# Altium schematic sheet Fan_Remote_001e.SchDoc(Fan_Remote_001e)
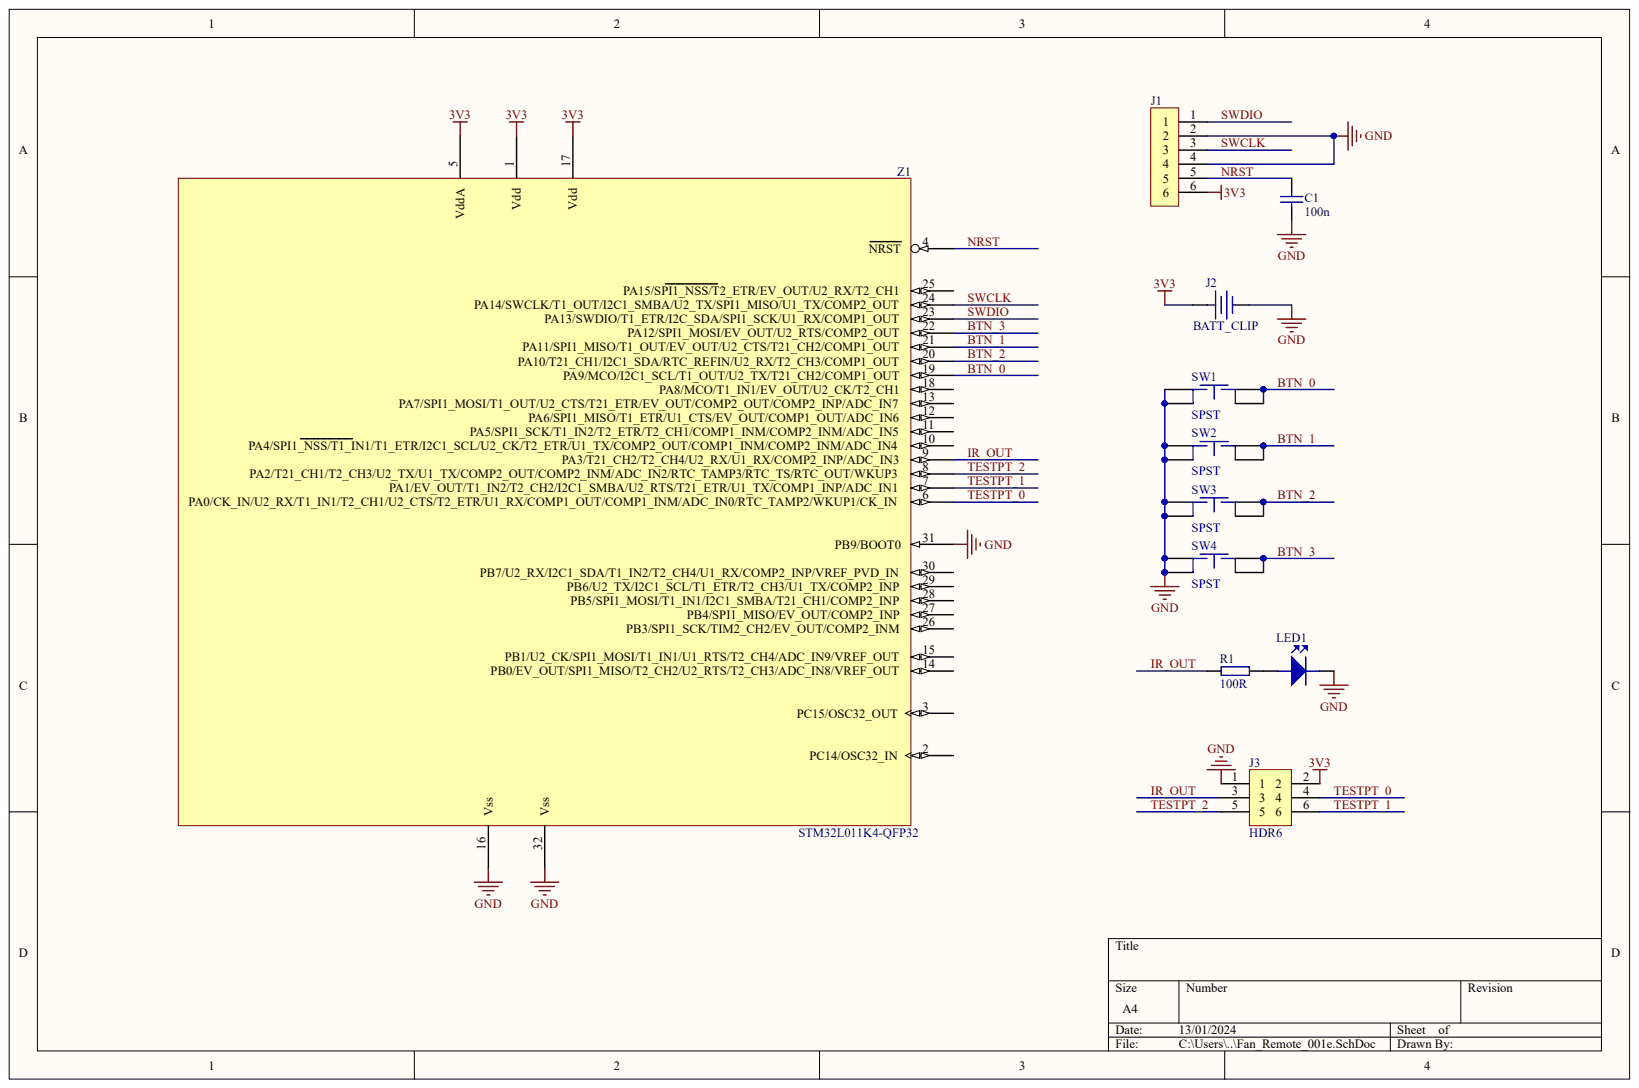
[diagram p0: Fan_Remote_001e.SchDoc]

## Parts
C1  100n
J1  76382-306LF  (value not drawn)
J2  BATT_CLIP
J3  HDR6
LED1  IRED  (value not drawn)
R1  100R
SW1  SPST
SW2  SPST
SW3  SPST
SW4  SPST
Z1  STM32L011K4-QFP32

## Nets
3V3: J1.6 J2.+ J3.2 Z1.1(Vdd) Z1.5(VddA) Z1.17(Vdd)
GND: C1.1 J1.2 J1.4 J2.- J3.1 LED1.K SW1.1 SW1.2 SW2.1 SW2.2 SW3.1 SW3.2 SW4.1 SW4.2 Z1.16(Vss) Z1.31(PB9/BOOT0) Z1.32(Vss)
BTN_0: SW1.3 SW1.4 Z1.19(PA9/MCO/I2C1_SCL/T1_OUT/U2_TX/T21_CH2/COMP1_OUT)
BTN_1: SW2.3 SW2.4 Z1.21(PA11/SPI1_MISO/T1_OUT/EV_OUT/U2_CTS/T21_CH2/COMP1_OUT)
BTN_2: SW3.3 SW3.4 Z1.20(PA10/T21_CH1/I2C1_SDA/RTC_REFIN/U2_RX/T2_CH3/COMP1_OUT)
BTN_3: SW4.3 SW4.4 Z1.22(PA12/SPI1_MOSI/EV_OUT/U2_RTS/COMP2_OUT)
IR_OUT: J3.3 R1.2 Z1.9(PA3/T21_CH2/T2_CH4/U2_RX/U1_RX/COMP2_INP/ADC_IN3)
NRST: C1.2 J1.5 Z1.4(NRST)
SWCLK: J1.3 Z1.24(PA14/SWCLK/T1_OUT/I2C1_SMBA/U2_TX/SPI1_MISO/U1_TX/COMP2_OUT)
SWDIO: J1.1 Z1.23(PA13/SWDIO/T1_ETR/I2C_SDA/SPI1_SCK/U1_RX/COMP1_OUT)
TESTPT_0: J3.4 Z1.6(PA0/CK_IN/U2_RX/T1_IN1/T2_CH1/U2_CTS/T2_ETR/U1_RX/COMP1_OUT/COMP1_INM/ADC_IN0/RTC_TAMP2/WKUP1/CK_IN)
TESTPT_1: J3.6 Z1.7(PA1/EV_OUT/T1_IN2/T2_CH2/I2C1_SMBA/U2_RTS/T21_ETR/U1_TX/COMP1_INP/ADC_IN1)
TESTPT_2: J3.5 Z1.8(PA2/T21_CH1/T2_CH3/U2_TX/U1_TX/COMP2_OUT/COMP2_INM/ADC_IN2/RTC_TAMP3/RTC_TS/RTC_OUT/WKUP3)
NetLED1_A: LED1.A R1.1

Not connected: Z1 2(PC14/OSC32_IN) 3(PC15/OSC32_OUT) 10(PA4/SPI1_NSS/T1_IN1/T1_ETR/I2C1_SCL/U2_CK/T2_ETR/U1_TX/COMP2_OUT/COMP1_INM/COMP2_INM/ADC_IN4) 11(PA5/SPI1_SCK/T1_IN2/T2_ETR/T2_CH1/COMP1_INM/COMP2_INM/ADC_IN5) 12(PA6/SPI1_MISO/T1_ETR/U1_CTS/EV_OUT/COMP1_OUT/ADC_IN6) 13(PA7/SPI1_MOSI/T1_OUT/U2_CTS/T21_ETR/EV_OUT/COMP2_OUT/COMP2_INP/ADC_IN7) 14(PB0/EV_OUT/SPI1_MISO/T2_CH2/U2_RTS/T2_CH3/ADC_IN8/VREF_OUT) 15(PB1/U2_CK/SPI1_MOSI/T1_IN1/U1_RTS/T2_CH4/ADC_IN9/VREF_OUT) 18(PA8/MCO/T1_IN1/EV_OUT/U2_CK/T2_CH1) 25(PA15/SPI1_NSS/T2_ETR/EV_OUT/U2_RX/T2_CH1) 26(PB3/SPI1_SCK/TIM2_CH2/EV_OUT/COMP2_INM) 27(PB4/SPI1_MISO/EV_OUT/COMP2_INP) 28(PB5/SPI1_MOSI/T1_IN1/I2C1_SMBA/T21_CH1/COMP2_INP) 29(PB6/U2_TX/I2C1_SCL/T1_ETR/T2_CH3/U1_TX/COMP2_INP) 30(PB7/U2_RX/I2C1_SDA/T1_IN2/T2_CH4/U1_RX/COMP2_INP/VREF_PVD_IN)

## Library data of the parts
C1 = (no symbol name): Vendor: Digikey; Vendor ID: 399-C0603C104Z4VAC7867CT-ND; Value: 100n
J1 = (no symbol name): Vendor: Digikey; Vendor ID: 609-1282-ND; Value: 76382-306LF
J2 = (no symbol name): Vendor: Digikey; Vendor ID: 36-2898-ND
J3 = (no symbol name): Vendor: Digikey; Vendor ID: 952-2121-ND
LED1 = (no symbol name): Vendor: Digikey; Vendor ID: 754-2219-ND
R1 = (no symbol name): Vendor: Digikey; Vendor ID: 311-100HRCT-ND; Value: 100R
SW1-SW4 = (no symbol name): Vendor: Digikey; Vendor ID: 450-2161-ND; Value: SPST
Z1 = (no symbol name): Vendor: Digikey; Vendor ID: 497-17480-ND
